# Altium schematic sheet Power_schematic.SchDoc(Power_schematic)
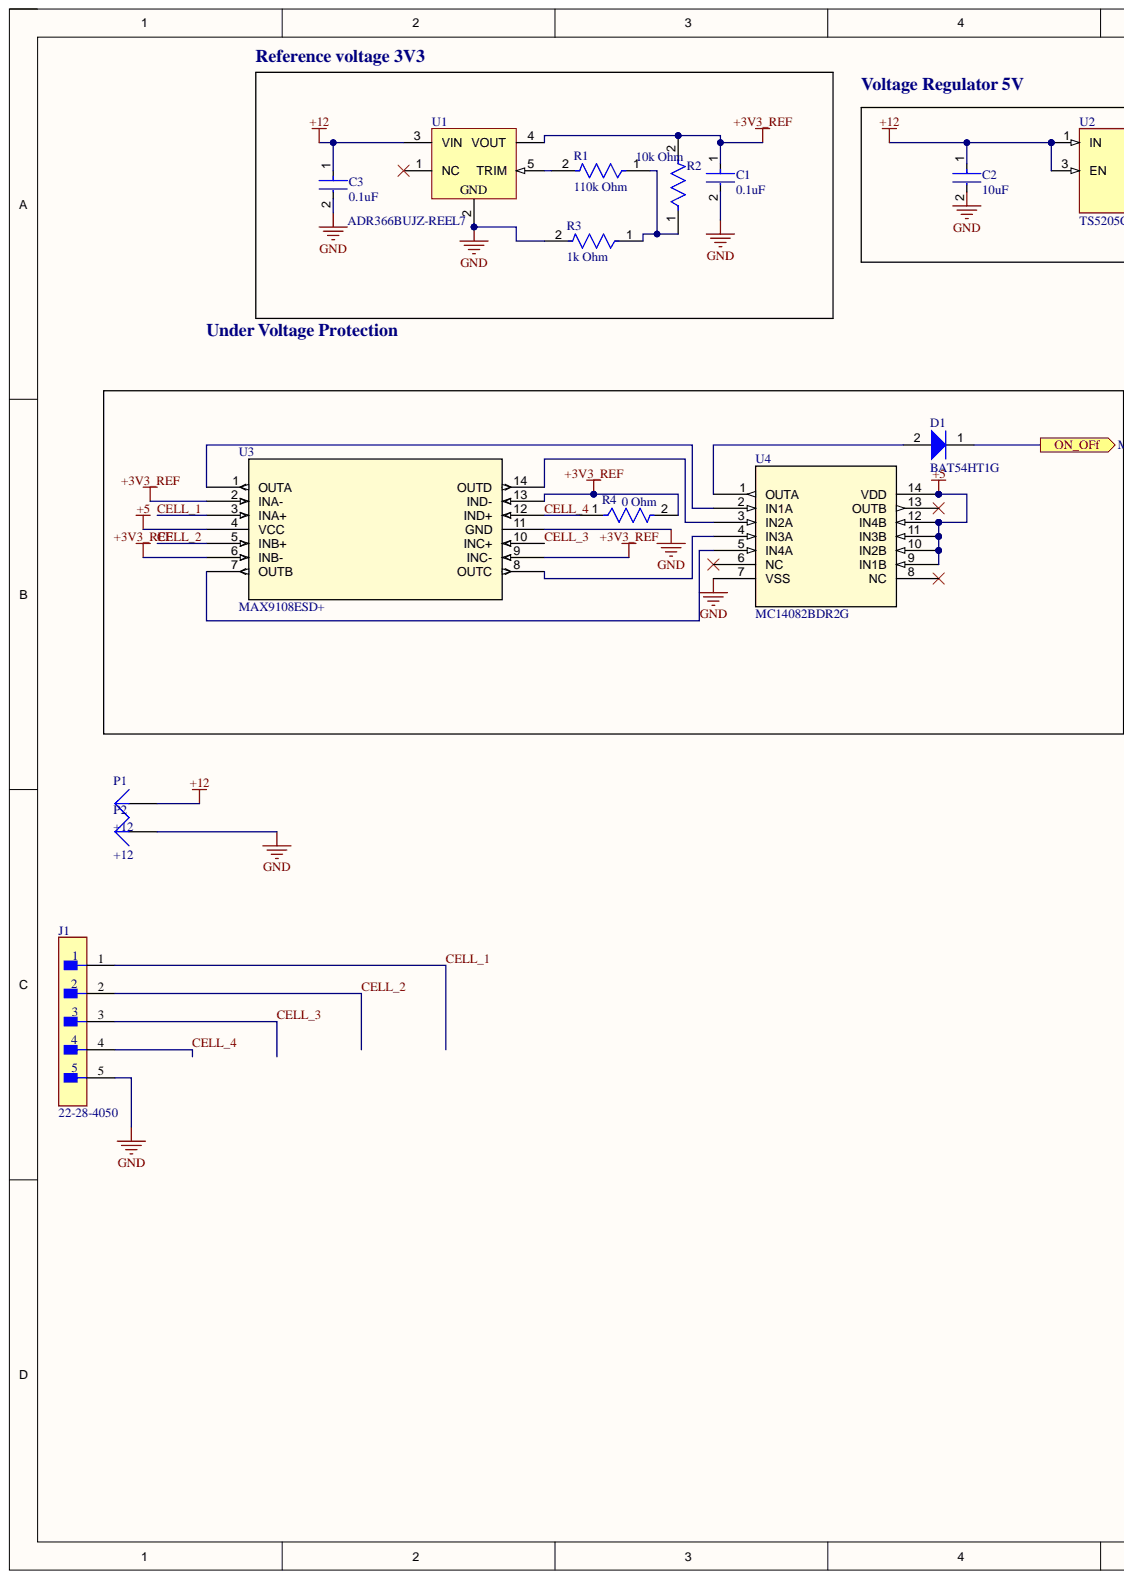
[diagram p0: Power_schematic.SchDoc, left]
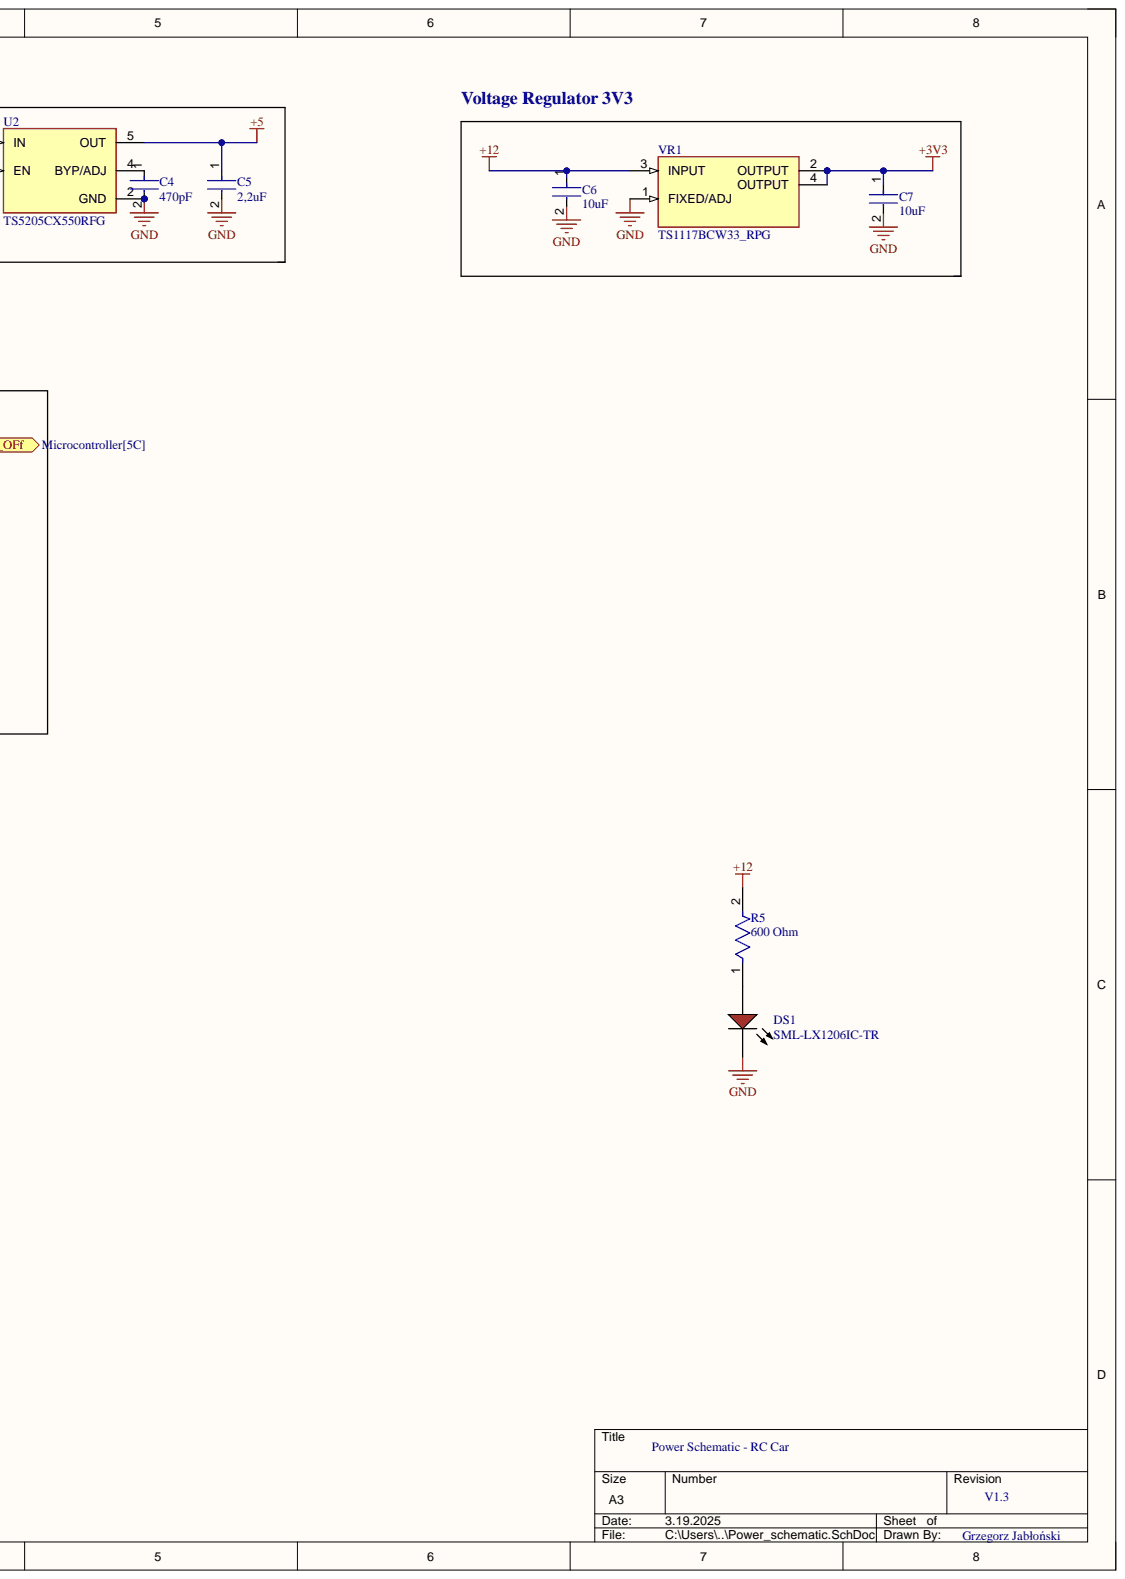
[diagram p1: Power_schematic.SchDoc, right]

## Parts
C1  0.1uF  [FP-CC0805-0_85-MFG]
C2  10uF  [FP-CC0805-0_85-MFG]
C3  0.1uF  [FP-CC0805-0_85-MFG]
C4  470pF  [FP-CC0805-0_85-MFG]
C5  2,2uF  [FP-CC0805-0_85-MFG]
C6  10uF  [FP-CC0805-0_85-MFG]
C7  10uF  [FP-CC0805-0_85-MFG]
D1  BAT54HT1G  [ONSC-SOD-323-2-477-02_V]
DS1  SML-LX1206IC-TR  [LUMX-SML-LX1206IC_V]
J1  22-28-4050  [FP-22-28-4050-MFG]
P1  +12  [PIN1]
P2  +12  [PIN1]
R1  110k Ohm  [FP-RMCF0805-MFG]
R2  10k Ohm  [FP-RMCF0805-MFG]
R3  1k Ohm  [FP-RMCF0805-MFG]
R4  0 Ohm  [FP-RMCF0805-MFG]
R5  600 Ohm  [FP-RMCF0805-MFG]
U1  ADR366BUJZ-REEL7  [ADI-UJ-5_L]
U2  TS5205CX550RFG  [SOT95P270X125-5N]
U3  MAX9108ESD+  [21-0041B_14]
U4  MC14082BDR2G  [SOIC-14_NB_ONS]
VR1  TS1117BCW33_RPG  [VREG_TS1117BCW50_RPG]

## Ports
ON_OFf

## Nets
+3V3: C7.1 VR1.2(OUTPUT) VR1.4(OUTPUT)
+3V3_REF: C1.1 R2.2 R4.2 U1.4(VOUT) U3.2(INA-) U3.6(INB-) U3.9(INC-) U3.13(IND-)
+5: C5.1 U2.5(OUT) U3.4(VCC) U4.9(IN1B) U4.10(IN2B) U4.11(IN3B) U4.12(IN4B) U4.14(VDD)
+12: C2.1 C3.1 C6.1 P1.1 R5.2 U1.3(VIN) U2.1(IN) U2.3(EN) VR1.3(INPUT)
GND: C1.2 C2.2 C3.2 C4.2 C5.2 C6.2 C7.2 DS1.2 J1.5 P2.1 R3.2 U1.2 U2.2(GND) U3.11(GND) U4.7(VSS) VR1.1(FIXED/ADJ)
CELL_1: J1.1 U3.3(INA+)
CELL_2: J1.2 U3.5(INB+)
CELL_3: J1.3 U3.10(INC+)
CELL_4: J1.4 R4.1 U3.12(IND+)
ON_OFf: D1.1 ; port ON_OFf
NetC4_1: C4.1 U2.4(BYP/ADJ)
NetD1_2: D1.2 U4.1(OUTA)
NetDS1_1: DS1.1 R5.1
NetR1_1: R1.1 R2.1 R3.1
NetR1_2: R1.2 U1.5(TRIM)
NetU3_1: U3.1(OUTA) U4.2(IN1A)
NetU3_7: U3.7(OUTB) U4.5(IN4A)
NetU3_8: U3.8(OUTC) U4.4(IN3A)
NetU3_14: U3.14(OUTD) U4.3(IN2A)

Not connected: U1 1(NC); U4 6(NC) 8(NC) 13(OUTB)

## Library data of the parts
C1-C7 = CMP-2100-03606-3: CAP CER 0.1UF 50V X7R 0805; Manufacturer: Yageo; Manufacturer Part Number: CC0805KRX7R9BB104; Capacitance: 100 nF; Material: Ceramic; Tolerance: 0.1; Value: 100 nF; Automotive: No; Category: Cap; Component Kind: Standard; ComponentLink2Description: Datasheet; ComponentLink2URL: http://www.yageo.com/documents/recent/UPY-GPHC_Y5V_6.3V-to-50V_8.pdf; Device Class L1: Passive Components; Device Class L2: Capacitors; Device Class L3: Ceramic Capacitors; Digikey Description: CAP CER 0.1UF 50V X7R 0805; Footprint Url: http://www.yageo.com/exep/pages/download/literatures/UPY-C_GEN_24.pdf; Height: 0.95mm; Ipc Land Pattern Name: CAPC200125X85; Lead Free: Yes; Library Name: Altium Content Vault; Mouser Description: Multilayer Ceramic Capacitors MLCC - SMD/SMT 100nF 50V X7R 10%; Octopart: https://octopart.com/cc0805krx7r9bb104-yageo-192993; Package: CC0805-0.85; RoHS: TRUE; Temperature: -30°C to +85°C; Temperature Characteristic: X7R; Temperature Range High: +85°C; Temperature Range Low: -30°C; Voltage Rating: 50 V
D1 = CMP-1055-00462-1: Schottky Barrier Diode, 2-Pin SOD-323, Pb-Free, Tape and Reel; Manufacturer: On Semiconductor; Configuration: Single; IFSM Max (A): 0.6; trr Max (ns): 5; Component Kind: Standard; ComponentLink1Description: Manufacturer URL; ComponentLink1URL: http://www.onsemi.com/; ComponentLink2Description: Datasheet; ComponentLink2URL: http://www.onsemi.cn/pub_link/Collateral/BAT54HT1-D.PDF; ComponentLink3Description: Package Specification; ComponentLink3URL: http://www.onsemi.com/pub_link/Collateral/477-02.PDF.1; DatasheetVersion: Rev. 6; Library Name: Altium Content Vault; Mounting Technology: Surface Mount; PackageDescription: 2-Pin SOD, Body 1.7 x 1.25 mm; PackageReference: SOD-323-2-477-02; PackageVersion: Rev. H, 03/2007; Packing: Tape and Reel; PartNumber: BAT54HT1G; RoHS: TRUE
DS1 = CMP-2000-07123-1: LED Red, 65 mW, -40 to 85 degC, 2-Pin SMD, RoHS, Tape and Reel; Manufacturer: Lumex; Intensity: 6mcd; LED Material: GaAsP; Lens Appearance: ColorlessNonDiffused; Number of LEDs: 1; Peak Wavelength: 635nm; Pin_Count: 2; Reverse Voltage: 5(Min)V; Type: Uni-Color; Viewing Angle: 160; Color: Red; Component Kind: Standard; Datasheet URL: http://www.lumex.com/content/files/ProductAttachment/SML-LX1206IC-TR.pdf; Datasheet Version: Rev. J, 08/2012; Dimension: 3.2x1.6x1.1mm; Dominant Wavelength: N/A; ECCN: EAR99; Family: SML-LX1206IC; HTS: 8541402000; Lens Dimensions: 2x1.6x0.5mm; Library Name: Altium Content Vault; Manufacturer URL: http://www.lumexinstruments.com/; Mounting: SurfaceMount; Mounting Technology: SurfaceMount; Operating Temperature: -25to85C; Package Description: 2-Pin Surface Mount Device, Body 3.2 x 1.5 mm; Package Reference: SML-LX1206IC; Packaging: TapeandReel; Part No: SML-LX1206IC-TR; RoHS: Unknown; Supplier: Lumex; Supplier Standard Pack: 3,000; Supplier_Package: SMD
J1 = CMP-00257-00278173-1: 22-28-4050 KK® 254 Breakaway Header, Vertical, 5 Circuits, Tin (Sn) Plating, Mating Pin Length 6.09mm; NexarPartId: 278173; Connector Type: Male Pin; Contact Resistance: 20mΩ; Current Rating: 4A; Gender: Male; Glow Wire Compliant: Non-compliant; Max Voltage Rating (DC): 250.25kV; Number of Circuits: 5; Pitch: 2.54mm; Voltage Rating: 250V; Color: Black; Component Kind: Standard; Contact Material: Brass; Contact Mating Length: 6.096mm; Contact Plating: Tin; Flammability Rating: UL94 V-0; Height: 2.29mm; Housing Color: Black; Housing Material: Thermoplastic; Lead Free: Lead Free; Lead Pitch: 2.54mm; Length: 12.7mm; Library Name: Altium Content Vault; Max Operating Temperature: 75°C; Min Operating Temperature: 0°C; Mount: Through Hole; Number of Contacts: 5; Number of Positions: 5; Number of Rows: 1; Orientation: Vertical; Packaging: Bulk; Radiation Hardening: No; REACH SVHC: Unknown; RoHS: Compliant; Schedule B: 8536694040; Termination: Solder; Voltage Rating (DC): 250V; Width: 2.49mm
P1 P2 = Plug: Component Kind: Standard; Description: Plug; LatestRevisionDate: 17-Jul-2002; LatestRevisionNote: Re-released for DXP Platform.; Library Name: Miscellaneous Connectors.IntLib; Signal Integrity: Connector; Publisher: Altium Limited
R1-R5 = CMP-26527-000017-1: RES 0 OHM JUMPER 1/8W 0805; Max Power Dissipation: 125mW; Resistance: 0mR; Case Code (Imperial): 0805; Case Code (Metric): 2012; Case/Package: 0805; Component Kind: Standard; Composition: Thick Film; Contact Plating: Tin; Depth: 1.25mm; Features: Automotive AEC-Q200; Height: 0.026inch; Lead Free: Lead Free; Length: 2mm; Library Name: Altium Content Vault; Max Operating Temperature: 155°C; Military Standard: Not; Min Operating Temperature: -55°C; Mount: Surface Mount; Number of Terminations: 2; Packaging: Tape and Reel; Power Rating: 125mW; Radiation Hardening: No; Width: 0.049inch
U1 = CMP-0784-00515-1: Low Power, Low Noise Voltage Reference with Sink / Source Capability, 3.3 V Output, 3.6 to 15 V Supply, Industrial, 5-pin SOT23 (UJ-5), Reel; Manufacturer: Analog Devices; Automotive Qualified: Yes; Max V Supply (V): 15; Min V Supply (V): 3.6; Ref Out TC (ppm/C): 9; Vout: 3.3V; Code_JEDEC: MO-193-AB; Component Kind: Standard; ComponentLink1Description: Manufacturer URL; ComponentLink1URL: http://www.analog.com/en/index.html; ComponentLink2Description: Datasheet; ComponentLink2URL: http://www.analog.com/static/imported-files/data_sheets/ADR360_361_363_364_365_366.pdf; ComponentLink3Description: Package Specification; ComponentLink3URL: http:/www.analog.com/static/imported-files/packages/PKG_PDF/TSOT(UJ)/UJ_5.pdf; ComponentLink4Description: URL Link1; ComponentLink4URL: Product URL:http://www.analog.com/en/special-linear-functions/voltage-references/adr366/products/product.html; DatasheetVersion: Rev. D; Library Name: Altium Content Vault; Mounting Technology: Surface Mount; Operating Temp Range: -40 to 125 degC; PackageDescription: 5-Pin Thin Small Outline Transistor Package, Body 2.9 x 1.6 mm, Pitch 0.95 mm; PackageReference: UJ-5; PackageVersion: 100708-A, 10/2008; Packing: Reel; PartNumber: ADR366BUJZ-REEL7
U2 = TS5205CX550RFG: MF: {'manufacturer': u'Taiwan Semiconductor Corporation'}; Availability: Unavailable; Component Kind: Standard; Library Name: TS5205CX550RFG.IntLib; MP: TS5205CX550RFG; Package: None; Price: None
U3 = MAX9108ESD+: No Description Available; Mfr_Name: Analog Devices / Maxim Integrated; Component Kind: Standard; Library Name: MAX9108ESD&plus_.IntLib; RefDes: RefDes; Type: Type
U4 = MC14082BDR2G: No Description Available; Mfr_Name: onsemi; Component Kind: Standard; Library Name: MC14082BDR2G.IntLib; RefDes: RefDes; Type: Type
VR1 = TS1117BCW50_RPG: Availability: Unavailable; Component Kind: Standard; Library Name: TS1117BCW50_RPG.IntLib; MP: TS1117BCW50_RPG; Package: None; Price: None
